# Altium schematic sheet Top.SchDoc(Top)
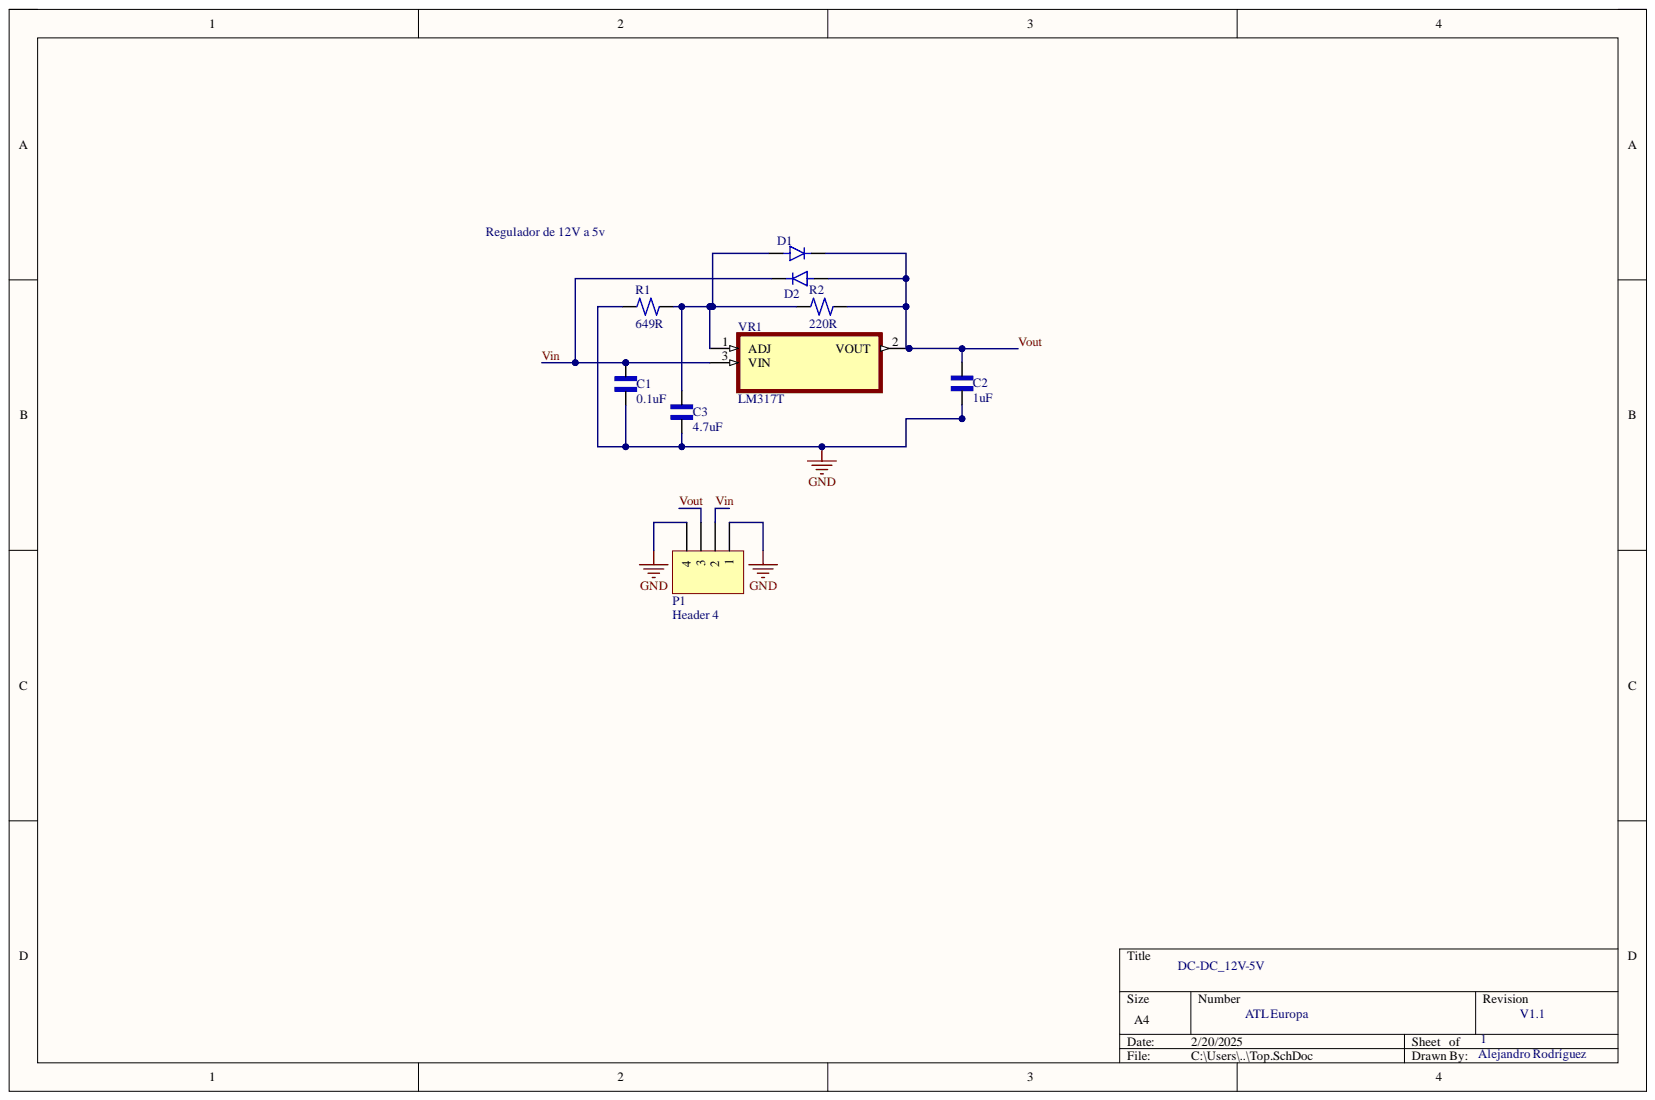
[diagram p0: Top.SchDoc]

## Parts
C1  0.1uF  [CAPC3216X110N]
C2  1uF  [CAPC3216X110N]
C3  4.7uF  [CAPC2012X88N]
D1  GF1A-E3/67A  [DIOM4325X250N]  (value not drawn)
D2  GF1A-E3/67A  [DIOM4325X250N]  (value not drawn)
P1  Header 4  [HDR1X4]
R1  649R  [0805]
R2  220R  [0805]
VR1  LM317T  [TO254P1054X470X1955-3]

## Nets
GND: C1.2 C2.2 C3.2 P1.1 P1.4 R1.1
Vin: C1.1 D2.C P1.2 VR1.3
Vout: C2.1 D1.C D2.A P1.3 R2.2 VR1.2
NetC3_1: C3.1 D1.A R1.2 R2.1 VR1.1

## Library data of the parts
C1 = C1206C104K5RAC7867: 0.1µF ±10% 50V Ceramic Capacitor X7R 1206 _3216 Metric_; MF: KEMET; Component Kind: Standard; Digi-Key_Part_Number: 399-C1206C104K5RAC7800TR-ND; Digi-Key_Purchase_URL: https://www.digikey.com/product-detail/en/kemet/C1206C104K5RAC7800/399-C1206C104K5RAC7800TR-ND/411248?utm_source=snapeda&utm_medium=aggregator&utm_campaign=symbol; MP: C1206C104K5RAC7867; Package: 1206 Kemet; SnapEDA_Link: https://www.snapeda.com/parts/C1206C104K5RAC7867/KEMET/view-part/436260/?ref=snap; Library Name: INSU.SchLib
C2 = T499A105K016ATE10K: 1.0uF Capacitadores de tantalio - SMD sólido 16V  1206 10% ESR=10ohms; MF: KEMET; MP: C1206C104K5RAC7867; Component Kind: Standard; Digi-Key_Part_Number: 399-C1206C104K5RAC7800TR-ND; Digi-Key_Purchase_URL: https://www.digikey.com/product-detail/en/kemet/C1206C104K5RAC7800/399-C1206C104K5RAC7800TR-ND/411248?utm_source=snapeda&utm_medium=aggregator&utm_campaign=symbol; Package: 1206 Kemet; SnapEDA_Link: https://www.snapeda.com/parts/C1206C104K5RAC7867/KEMET/view-part/436260/?ref=snap; Library Name: INSU.SchLib
C3 = C0805C475K4PACTU: 4.7µF ±10% 16V Ceramic Capacitor X5R 0805 _2012 Metric_; MF: KEMET; MP: C0805C106K4PACTU; Availability: Unavailable; Component Kind: Standard; Package: 0805 KEMET; Price: None; SnapEDA_Link: https://www.snapeda.com/parts/C0805C106K4PACTU/KEMET/view-part/609587/?ref=snap; Library Name: INSU.SchLib
D1 D2 = GF1A-E3/67A: Diodo Rectificador 1.0 Amp 50 Volt; MP: BZT52B4V7-G_RHG; Availability: Unavailable; Component Kind: Standard; Package: None; Price: None; Library Name: INSU.SchLib
P1 = Header 4: Header, 4-Pin; Component Kind: Standard; LatestRevisionDate: 17-Jul-2002; LatestRevisionNote: Re-released for DXP Platform.; Library Name: Miscellaneous Connectors.IntLib; Signal Integrity: Connector; Publisher: Altium Limited
R1 = RES: CRCW0805649RFKEA; MF: Vishay; MP: CRCW06034K70FKEA; Check_prices: https://www.snapeda.com/parts/CRCW06034K70FKEA/Vishay+Dale/view-part/?ref=eda; Component Kind: Standard; Package: 1508 Vishay; SnapEDA_Link: https://www.snapeda.com/parts/CRCW06034K70FKEA/Vishay+Dale/view-part/?ref=snap; Library Name: INSU.SchLib
R2 = RES: CRCW0805220RFKEA; MF: Vishay; MP: CRCW06034K70FKEA; Check_prices: https://www.snapeda.com/parts/CRCW06034K70FKEA/Vishay+Dale/view-part/?ref=eda; Component Kind: Standard; Package: 1508 Vishay; SnapEDA_Link: https://www.snapeda.com/parts/CRCW06034K70FKEA/Vishay+Dale/view-part/?ref=snap; Library Name: INSU.SchLib
VR1 = LM317T: 1.5-A, 40-V, adjustable linear voltage regulator 3-TO-220; MF: Texas Instruments; Check_prices: https://www.snapeda.com/parts/LM317T/Texas+Instruments/view-part/?ref=eda; Component Kind: Standard; MP: LM317T; Package: TO-220-3 Texas Instruments; SnapEDA_Link: https://www.snapeda.com/parts/LM317T/Texas+Instruments/view-part/?ref=snap; Library Name: INSU.SchLib
